# Altium schematic sheet Board_overview.SchDoc(Board_overview)
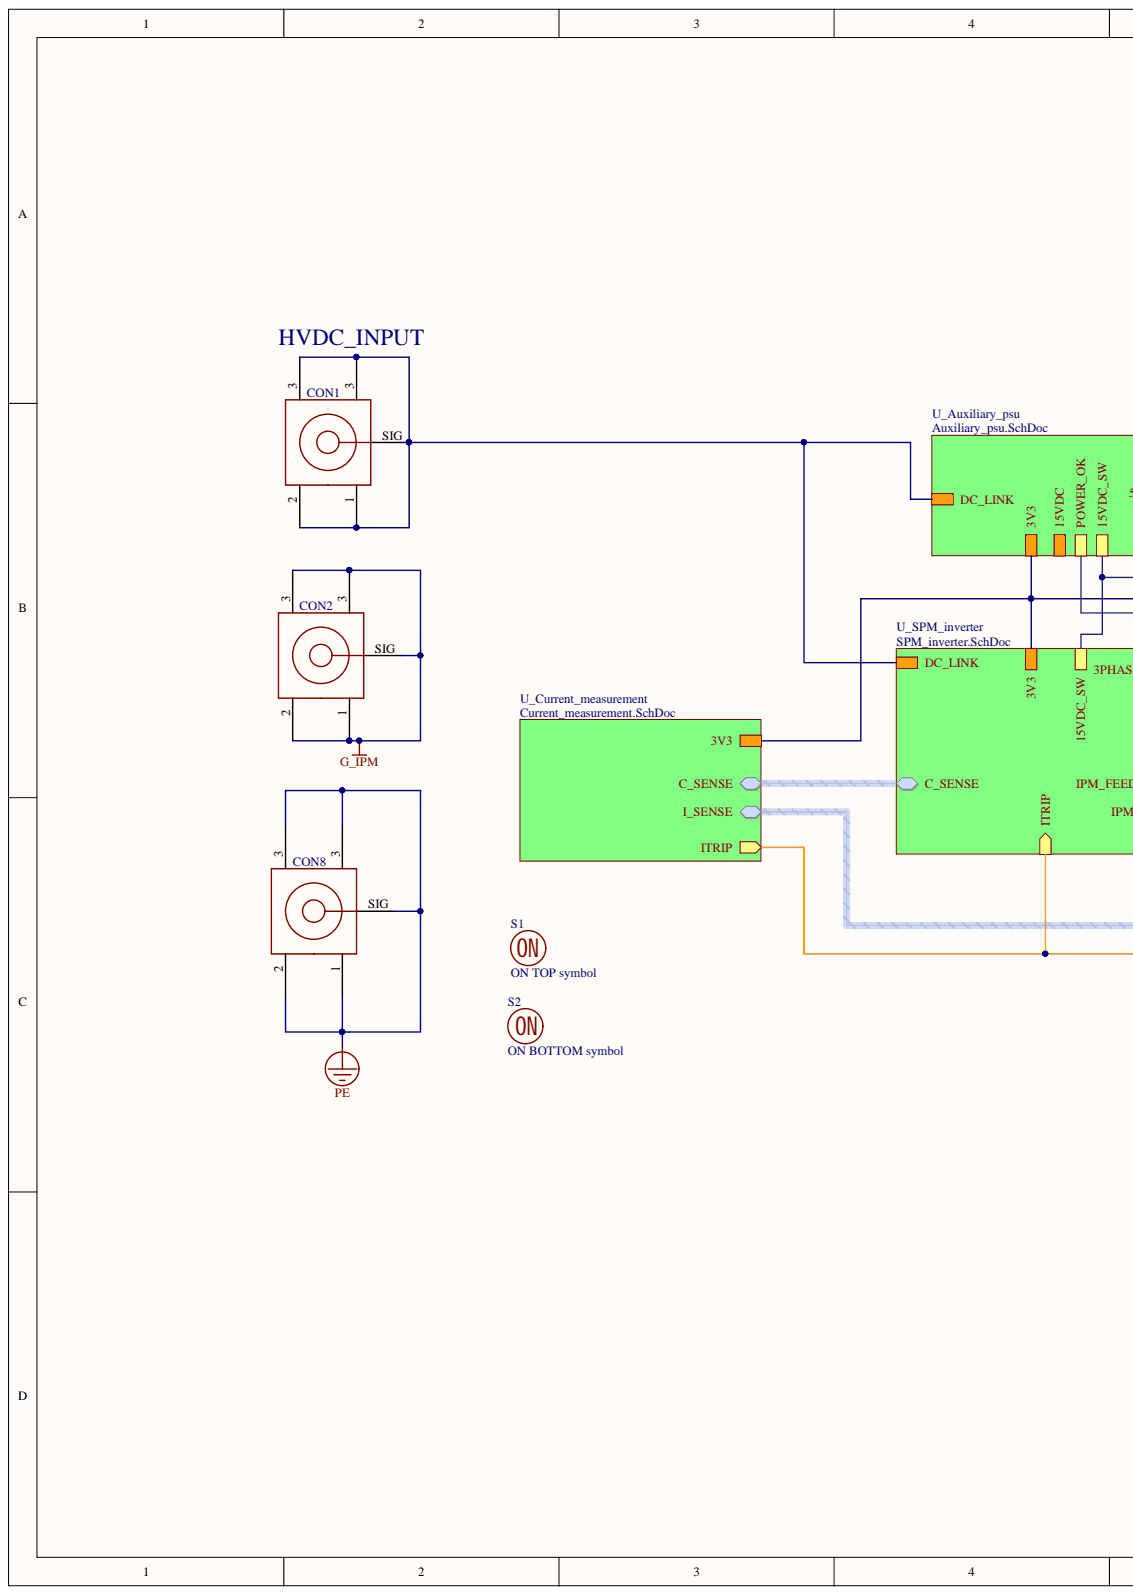
[diagram p0: Board_overview.SchDoc, left]
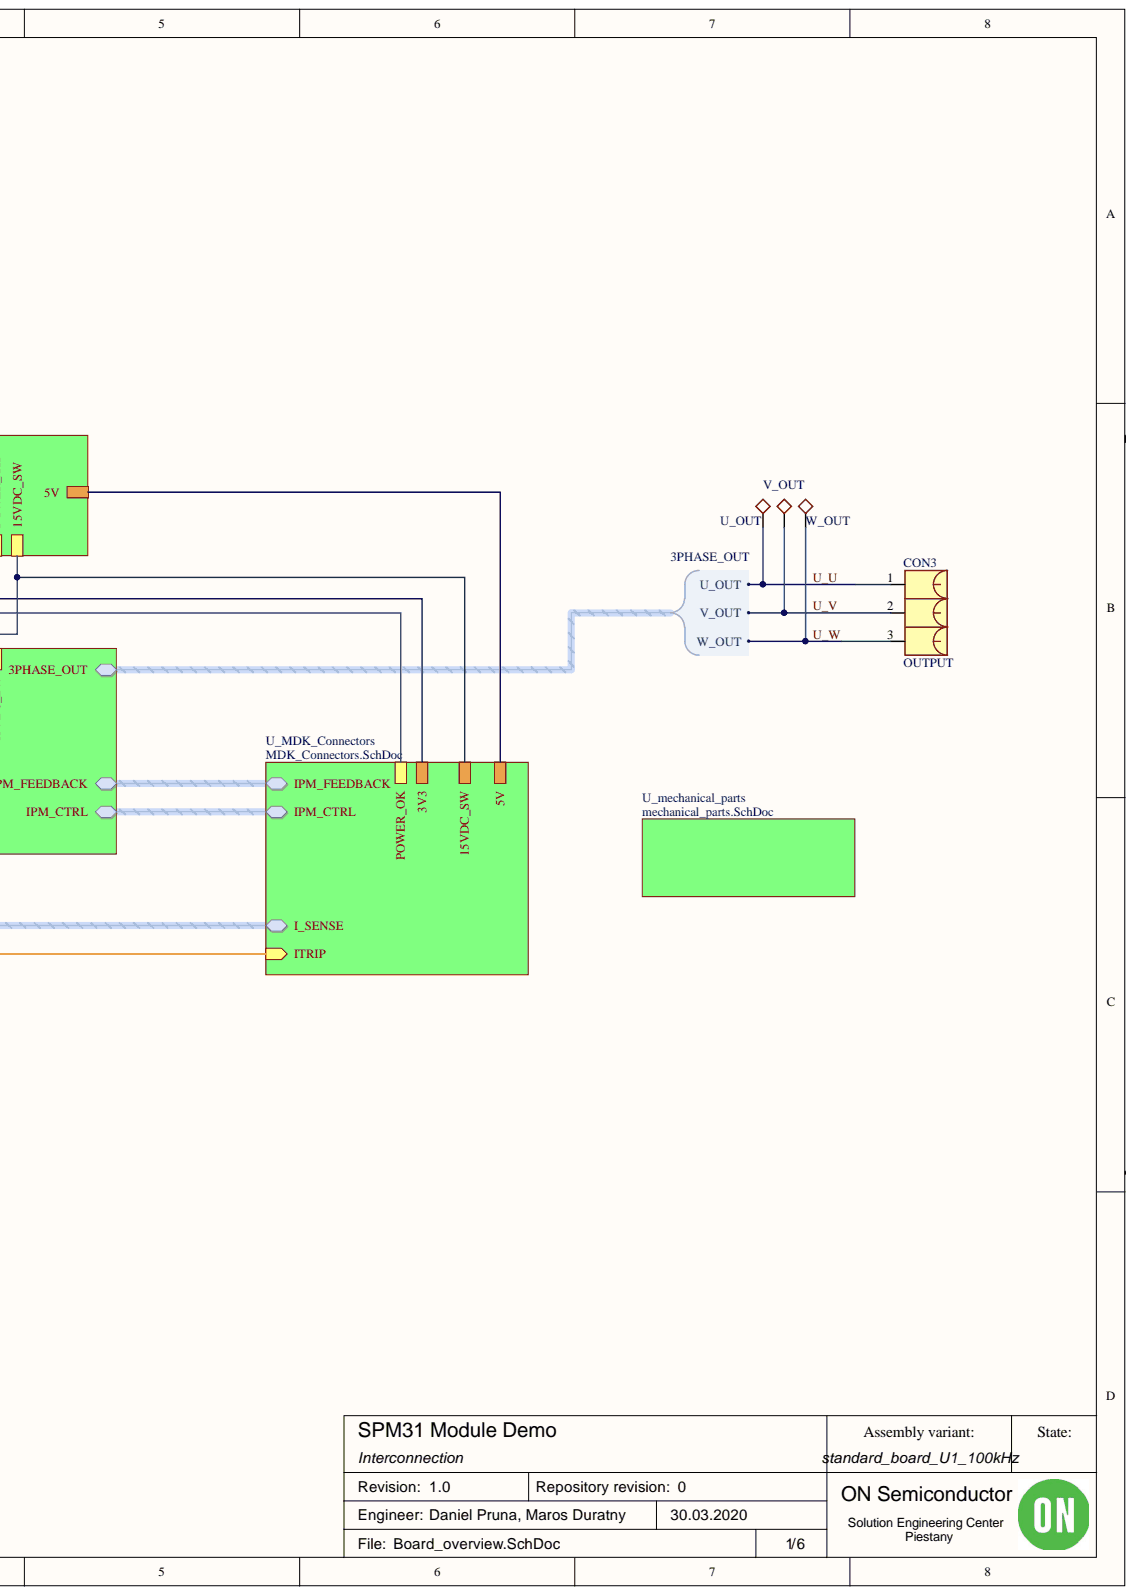
[diagram p1: Board_overview.SchDoc, right]

## Parts
CON1  RED  [CON_BANANA_CLIFF_4mm_TH_RED_FCR7350R]  (value not drawn)
CON2  BLACK  [CON_BANANA_CLIFF_4mm_TH_BLACK_FCR7350B]  (value not drawn)
CON3  OUTPUT  [CON_3P_WE_PTH_691313710003]
CON8  Green  [CON_BANANA_CLIFF_4mm_TH_GREEN_FCR7350G]  (value not drawn)
S1  ON TOP symbol  [SYMBOL_ON_SEMI_LOGO_400_MIL]  (no BOM)
S2  ON BOTTOM symbol  [SYMBOL_ON_SEMI_LOGO_400_MIL]  (no BOM)
U_OUT  DC_LINK  [TEST_POINT_KEYSTONE_5005_RED]  (value not drawn)
V_OUT  DC_LINK  [TEST_POINT_KEYSTONE_5005_RED]  (value not drawn)
W_OUT  DC_LINK  [TEST_POINT_KEYSTONE_5005_RED]  (value not drawn)

## Nets
G_IPM: CON2.1 CON2.2 CON2.3 CON2.SIG
PE: CON8.1 CON8.2 CON8.3 CON8.SIG
U_U: CON3.1 U_OUT.1
U_V: CON3.2 V_OUT.1
U_W: CON3.3 W_OUT.1
NetCON1_1: CON1.1 CON1.2 CON1.3 CON1.SIG
N00033:
N00034:
N00035:
N00036:
N00037:
N00038:
N00039:
N00040:
N00041:
N00042:
N00043:
N00044:
N00045:
N00046:
N00047:
N00048:
N00049:
N00050:
N00051:
N00052:
N00053:
N00054:
N00055:
N00056:
N00057:

## Library data of the parts
CON1 = 71: Banana Test Connector, 4mm, Receptacle, PCB Mount, 24 A, 1 kV, Gold Plated Contacts, Red; Manufacturer Part Number: FCR7350R; Manufacturer: CLIFF Electronic Components; Number of contacts: 1; Documenation type: Datasheet; Supplier Part Number: 1854508; Supplier: Farnell; package type: PTH male right angle; Library Name: SPM31_demo.IntLib; ID: 71; Documentation URL: http://www.farnell.com/datasheets/816908.pdf?_ga=2.146380404.1353788038.1519821450-1750574409.1468396520; construction: 1 x 1; Component Kind: Standard
CON2 = 72: Banana Test Connector, 4mm, Receptacle, PCB Mount, 24 A, 1 kV, Gold Plated Contacts, Black; Manufacturer Part Number: FCR7350B; Manufacturer: CLIFF Electronic Components; Number of contacts: 1; Documenation type: Datasheet; Supplier Part Number: 1854507; Supplier: Farnell; package type: PTH male right angle; Library Name: SPM31_demo.IntLib; ID: 72; Documentation URL: http://www.farnell.com/datasheets/816908.pdf?_ga=2.146380404.1353788038.1519821450-1750574409.1468396520; construction: 1 x 1; Component Kind: Standard
CON3 = 691313710003: Pluggable Terminal Blocks WR-TBL Model3137 5mm 3Pin Cls Hztl PCBHdr; Manufacturer: Würth Elektronik; Number of contacts: 3; Documenation type: Datasheet; Supplier Part Number 2: 710-691313710003; Supplier Part Number: 691313710003; Supplier 2: Mouser; Supplier: Würth Elektronik; Pitch: 5 mm; package type: PTH male right angle; Manufacturer Part Number: 691313710003; Library Name: SPM31_demo.IntLib; Documentation URL: https://www.mouser.com/ds/2/445/6913137100xx-538102.pdf; construction: 1 x 3; Component Kind: Standard
CON8 = 149: Banana Test Connector, 4mm, Receptacle, PCB Mount, 24 A, 1 kV, Gold Plated Contacts, Green; Manufacturer Part Number: FCR7350G; Manufacturer: CLIFF Electronic Components; Number of contacts: 1; Edited by: maros; Documenation type: Datasheet; Database Table Name: connectors; Supplier Part Number: 419668; Supplier: Conrad; package type: PTH male right angle; Library Name: OnSemiParts.DbLib; ID: 149; Documentation URL: https://datasheet.octopart.com/FCR7350G-Cliff-datasheet-10027538.pdf; construction: 1 × 1; Component Kind: Standard
S1 S2 = symbol_ON_Semiconductor: Schematic and PCB symbol for ON Semiconductor logo; Type: Standard(No BOM); package type: overlay; Library Name: SPM31_demo.IntLib; ID: symbol_ON_Semiconductor
U_OUT V_OUT W_OUT = 5005: PTH testpoint eyelet 3.2mm red Keystone Electronics; Number of contacts: 1; Documenation type: Datasheet; Supplier Part Number 2: 534-5005; Supplier Part Number: 36-5005-ND; Supplier 2: Mouser; Supplier: Digi-Key; package type: testpoint eyelet; Manufacturer Part Number: 5005; Manufacturer: Keystone Electronics; Library Name: SPM31_demo.IntLib; Documentation URL: http://www.keyelco.com/product-pdf.cfm?p=1314; construction: 1 × 1; Component Kind: Standard
